# Altium schematic sheet [0] TOP.SchDoc
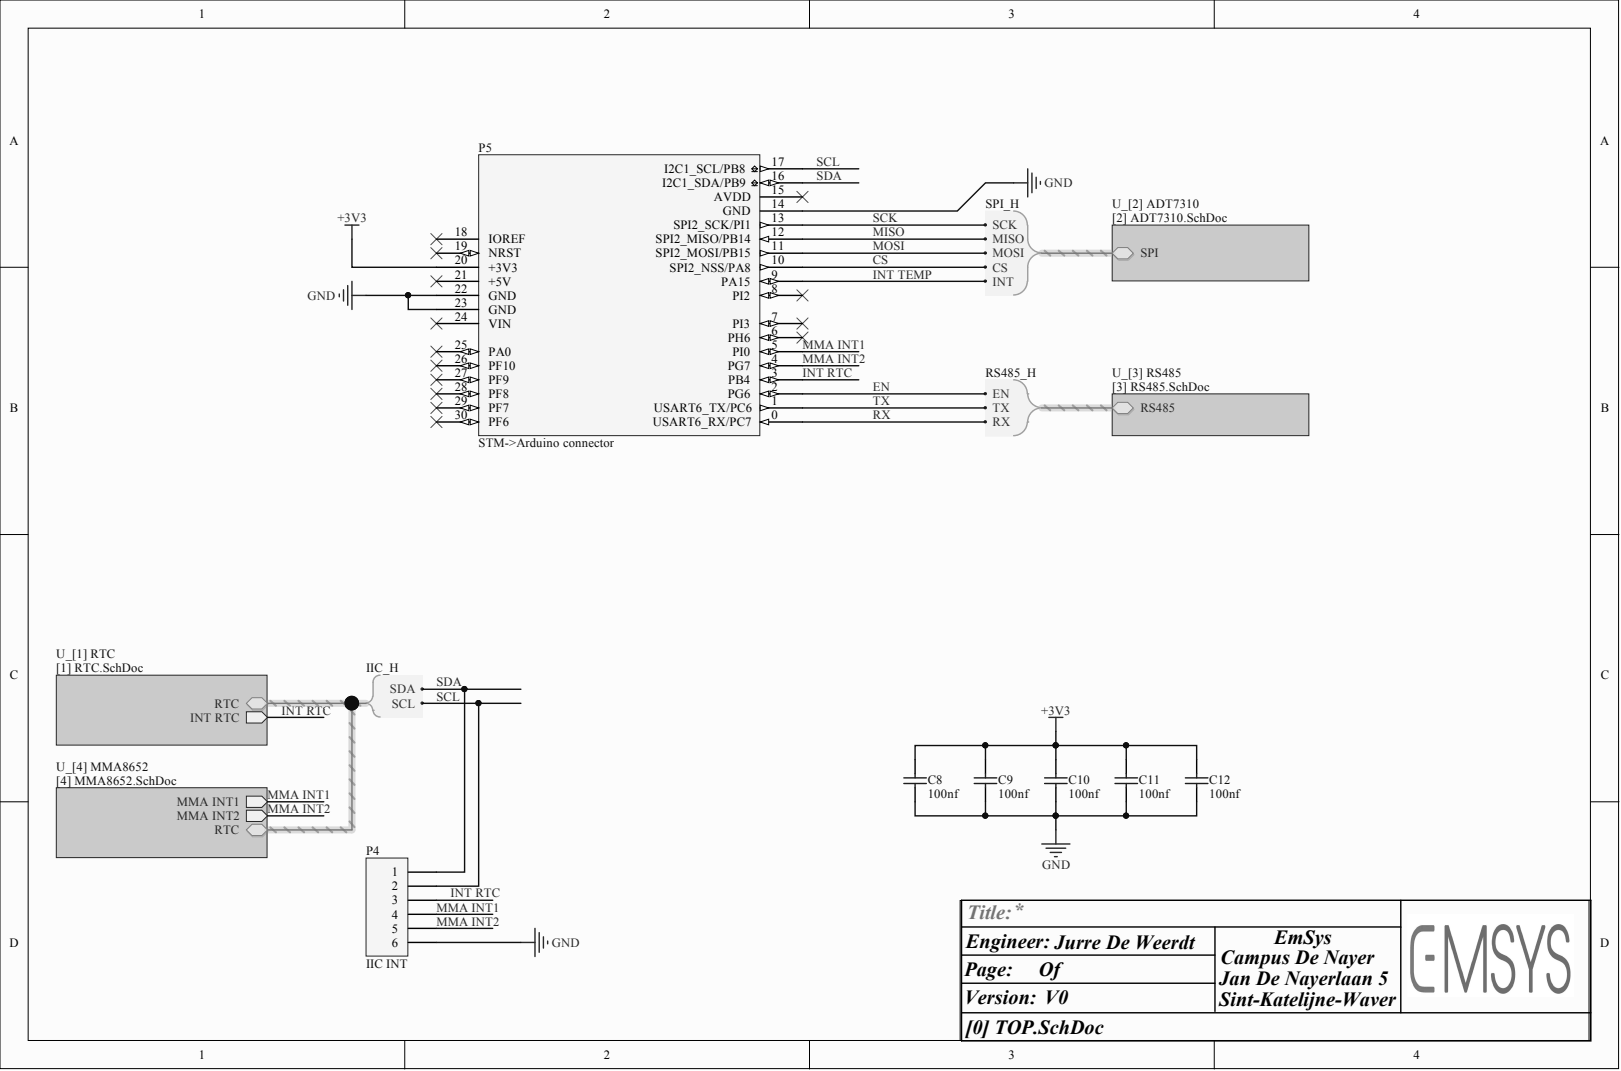
[diagram p0: [0] TOP.SchDoc]

## Parts
C8  100nf  [C0603]
C9  100nf  [C0603]
C10  100nf  [C0603]
C11  100nf  [C0603]
C12  100nf  [C0603]
P4  IIC INT  [HDR1X6H]
P5  STM->Arduino connector  [ArduinoUno]

## Nets
+3V3: C8.1 C9.1 C10.1 C11.1 C12.1 P5.20(+3V3)
GND: C8.2 C9.2 C10.2 C11.2 C12.2 P4.6 P5.14(GND) P5.22(GND) P5.23(GND)
CS: P5.10(SPI2_NSS/PA8)
EN: P5.2(PG6)
INT RTC: P4.3 P5.3(PB4)
INT TEMP: P5.9(PA15)
MISO: P5.12(SPI2_MISO/PB14)
MMA INT1: P4.4 P5.5(PI0)
MMA INT2: P4.5 P5.4(PG7)
MOSI: P5.11(SPI2_MOSI/PB15)
RX: P5.0(USART6_RX/PC7)
SCK: P5.13(SPI2_SCK/PI1)
SCL: P4.2 P5.17(I2C1_SCL/PB8)
SDA: P4.1 P5.16(I2C1_SDA/PB9)
TX: P5.1(USART6_TX/PC6)
NetP5_6: P5.6(PH6)
NetP5_7: P5.7(PI3)
NetP5_8: P5.8(PI2)
NetP5_15: P5.15(AVDD)
NetP5_18: P5.18(IOREF)
NetP5_19: P5.19(NRST)
NetP5_21: P5.21(+5V)
NetP5_24: P5.24(VIN)
NetP5_25: P5.25(PA0)
NetP5_26: P5.26(PF10)
NetP5_27: P5.27(PF9)
NetP5_28: P5.28(PF8)
NetP5_29: P5.29(PF7)
NetP5_30: P5.30(PF6)

## Library data of the parts
C8-C12 = Condensator: Standaard Condensator; Component Kind: Standard; Library Name: condensator.SchLib
P4 = Header 6H: Header, 6-Pin, Right Angle; Component Kind: Standard; LatestRevisionDate: 17-Jul-2002; LatestRevisionNote: Re-released for DXP Platform.; Library Name: Miscellaneous Connectors.IntLib; Signal Integrity: Connector; Publisher: Altium Limited
P5 = STM_Arduino_CON: Connector voor STM32F7 Discovery; Component Kind: Standard; Library Name: STM32F7Connector.SchLib
